# Altium schematic sheet connectors.SchDoc(connectors)
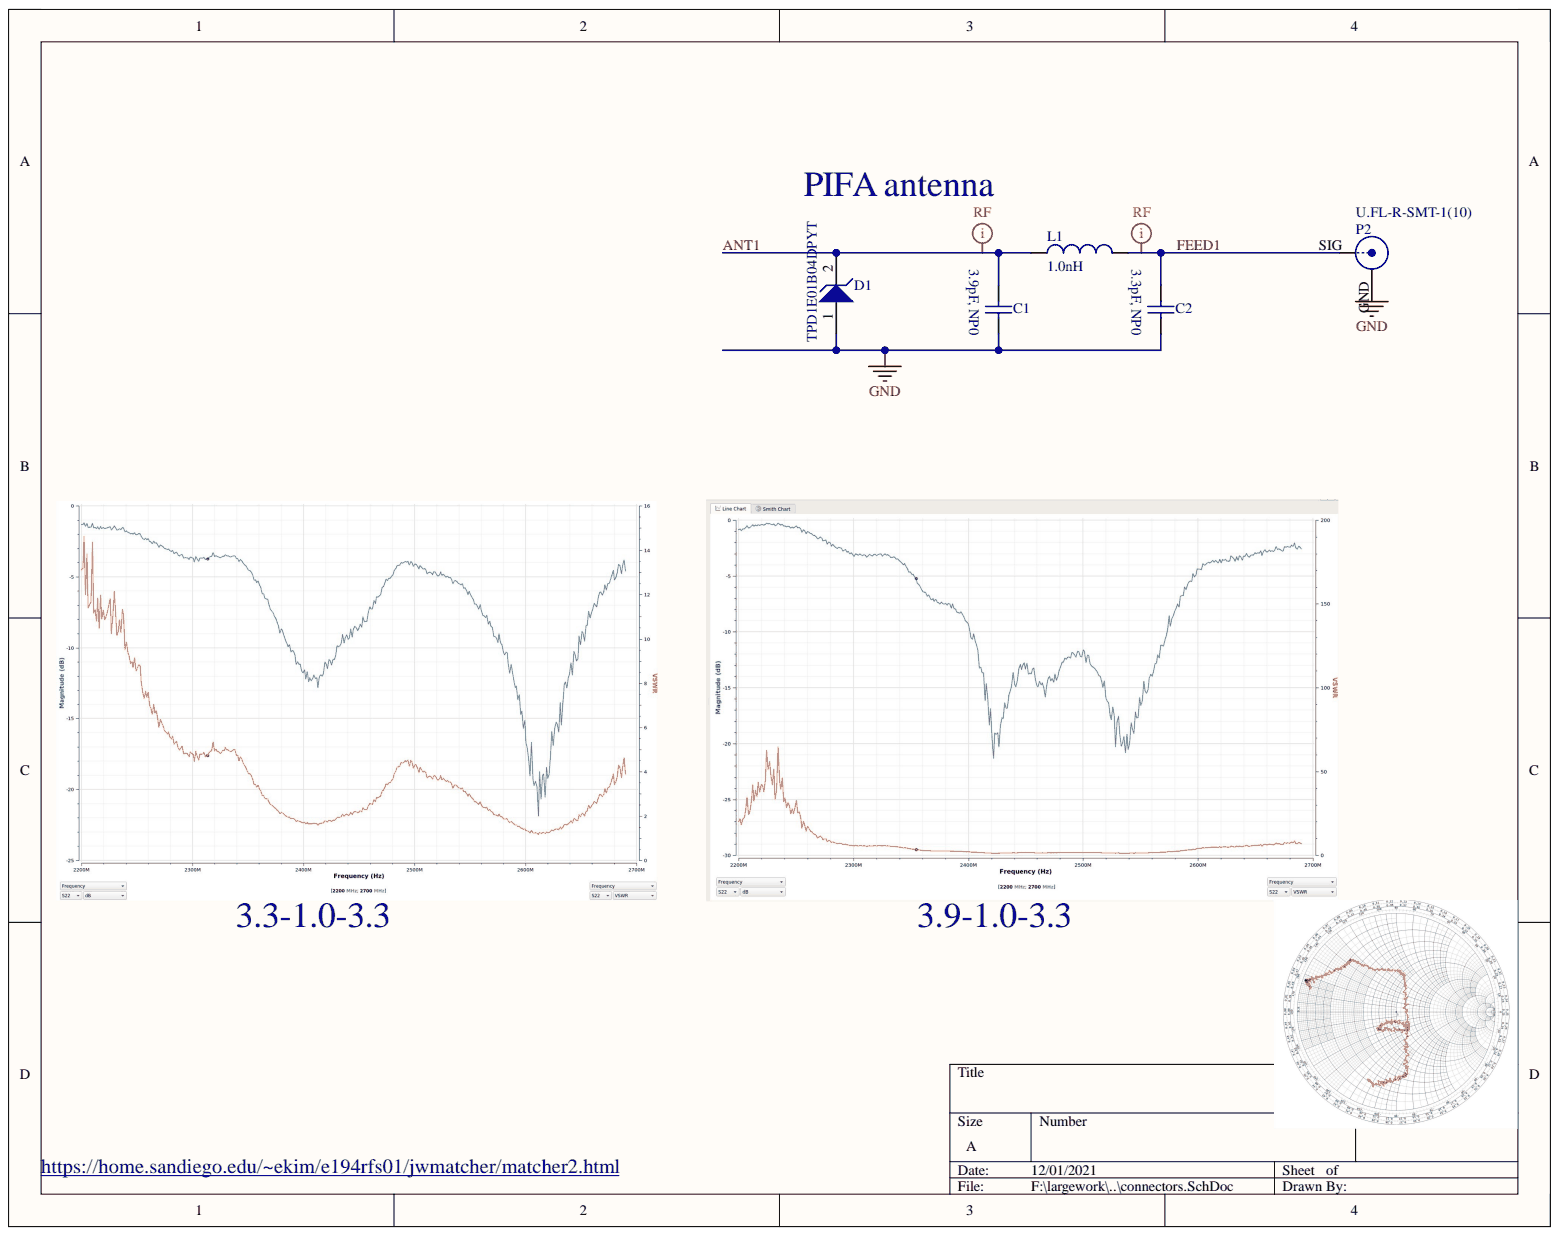
[diagram p0: connectors.SchDoc]

## Parts
C1  3.9pF, NP0  [B_CAP0402]
C2  3.3pF, NP0  [B_CAP0402]
D1  TPD1E01B04DPYT  [TI_PX1SON-N2]
L1  1.0nH  [B_IND0402]
P2  U.FL-R-SMT-1(10)  [HRS_U.FL]

## Nets
GND: C1.2 C2.2 D1.1 P2.GND
ANT1: C1.1 D1.2 L1.1
FEED1: C2.1 L1.2 P2.SIG

## Library data of the parts
C1 = Cap Semi: Capacitor (Semiconductor SIM Model); MPN: CL05C3R9CB5NNNC; MYPN: C-3p9-50-NP0-0402; VENDOR: Samsung; Component Kind: Standard; Library Name: Miscellaneous Devices.IntLib; Signal Integrity: Cap Semi; Simulation: CAP
C2 = Cap Semi: Capacitor (Semiconductor SIM Model); MPN: GRM1555C1H3R3CA01D; MYPN: C-3p3-50-NP0-0402; VENDOR: Murata; Component Kind: Standard; Library Name: Miscellaneous Devices.IntLib; Signal Integrity: Cap Semi; Simulation: CAP
D1 = D Zener: CPDUR24V; MYPN: D-TPD1E01B04DPYT; VENDOR: TI; Component Kind: Standard; LatestRevisionDate: 17-Jul-2002; LatestRevisionNote: Re-released for DXP Platform.; Library Name: Miscellaneous Devices.IntLib; MPN: TPD1E01B04DPYT; PackageDescription: Diode, Thru-Hole; 2 Leads; 0.7 in Pin Spacing; PackageReference: DIODE-0.7; Published: 8-Jun-2000; Signal Integrity: D Zener; Simulation: ZENER; Publisher: Altium Limited
L1 = Inductor: MPN: LQG15HS1N0S02D; Code_IEC: 1005; MYPN: L-1p0NH-0402; VENDOR: Murata; Code_JEITA: 0402; Component Kind: Standard; Description: Inductor; LatestRevisionDate: 29-May-2009; LatestRevisionNote: IPC-7351 Footprint Added.; Library Name: Miscellaneous Devices.IntLib; PackageDescription: Chip Inductor; PackageReference: 0402-A; Published: 8-Jun-2000; Signal Integrity: Inductor; Simulation: INDUCTOR; Publisher: Altium Limited
P2 = BNC: BNC Elbow Connector; MPN: P1163-0140R; MYPN: J-U.FL-SMT; VENDOR: Kaweei; Component Kind: Standard; LatestRevisionDate: 17-Jul-2002; LatestRevisionNote: Re-released for DXP Platform.; Library Name: Miscellaneous Connectors.IntLib; Signal Integrity: Connector; Publisher: Altium Limited
